# Altium schematic sheet SolarPanel.SchDoc(SolarPanel)
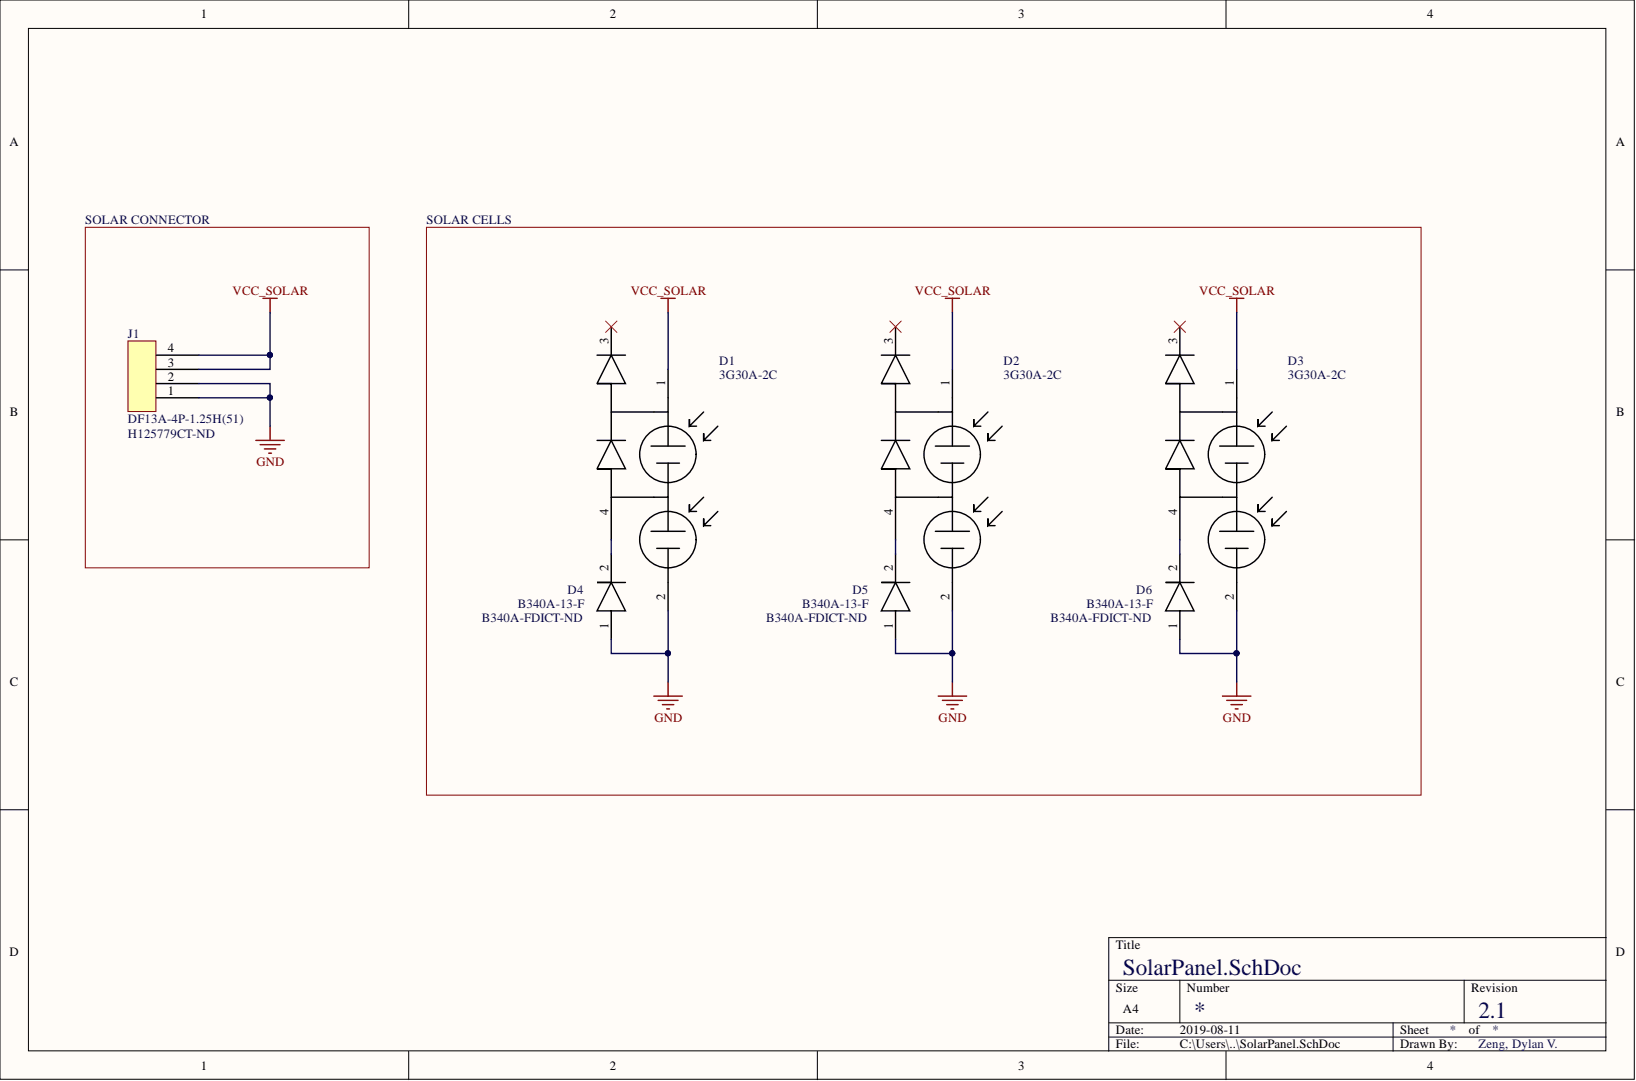
[diagram p0: SolarPanel.SchDoc]

## Parts
D1  3G30A-2C  [3G30A_2C]
D2  3G30A-2C  [3G30A_2C]
D3  3G30A-2C  [3G30A_2C]
D4  B340A-13-F  Supplier Part Number 1: B340A-FDICT-ND  [B340A-13-F]
D5  B340A-13-F  Supplier Part Number 1: B340A-FDICT-ND  [B340A-13-F]
D6  B340A-13-F  Supplier Part Number 1: B340A-FDICT-ND  [B340A-13-F]
J1  DF13A-4P-1.25H(51)  Supplier Part Number 1: H125779CT-ND  [DF13A-4P-1.25H(51)]

## Nets
GND: D1.2 D2.2 D3.2 D4.1 D5.1 D6.1 J1.1 J1.2
VCC_SOLAR: D1.1 D2.1 D3.1 J1.3 J1.4
NetD1_4: D1.4 D4.2
NetD2_4: D2.4 D5.2
NetD3_4: D3.4 D6.2

Not connected: D1 3; D2 3; D3 3

## Library data of the parts
D1-D3 = 3G30A-2C: Solar cell x2; Component Kind: Standard; Library Name: heron-general.IntLib
D4-D6 = B340A-13-F: Schottky diode; Supplier 1: Digi-Key; Component Kind: Standard; Library Name: heron-general.IntLib
J1 = DF13A-4P-1.25H(51): 4 pos DF13 right angle surface mount connector; Supplier 1: Digi-Key; Component Kind: Standard; Library Name: heron-general.IntLib
